# Altium schematic sheet Schem_Example.SchDoc
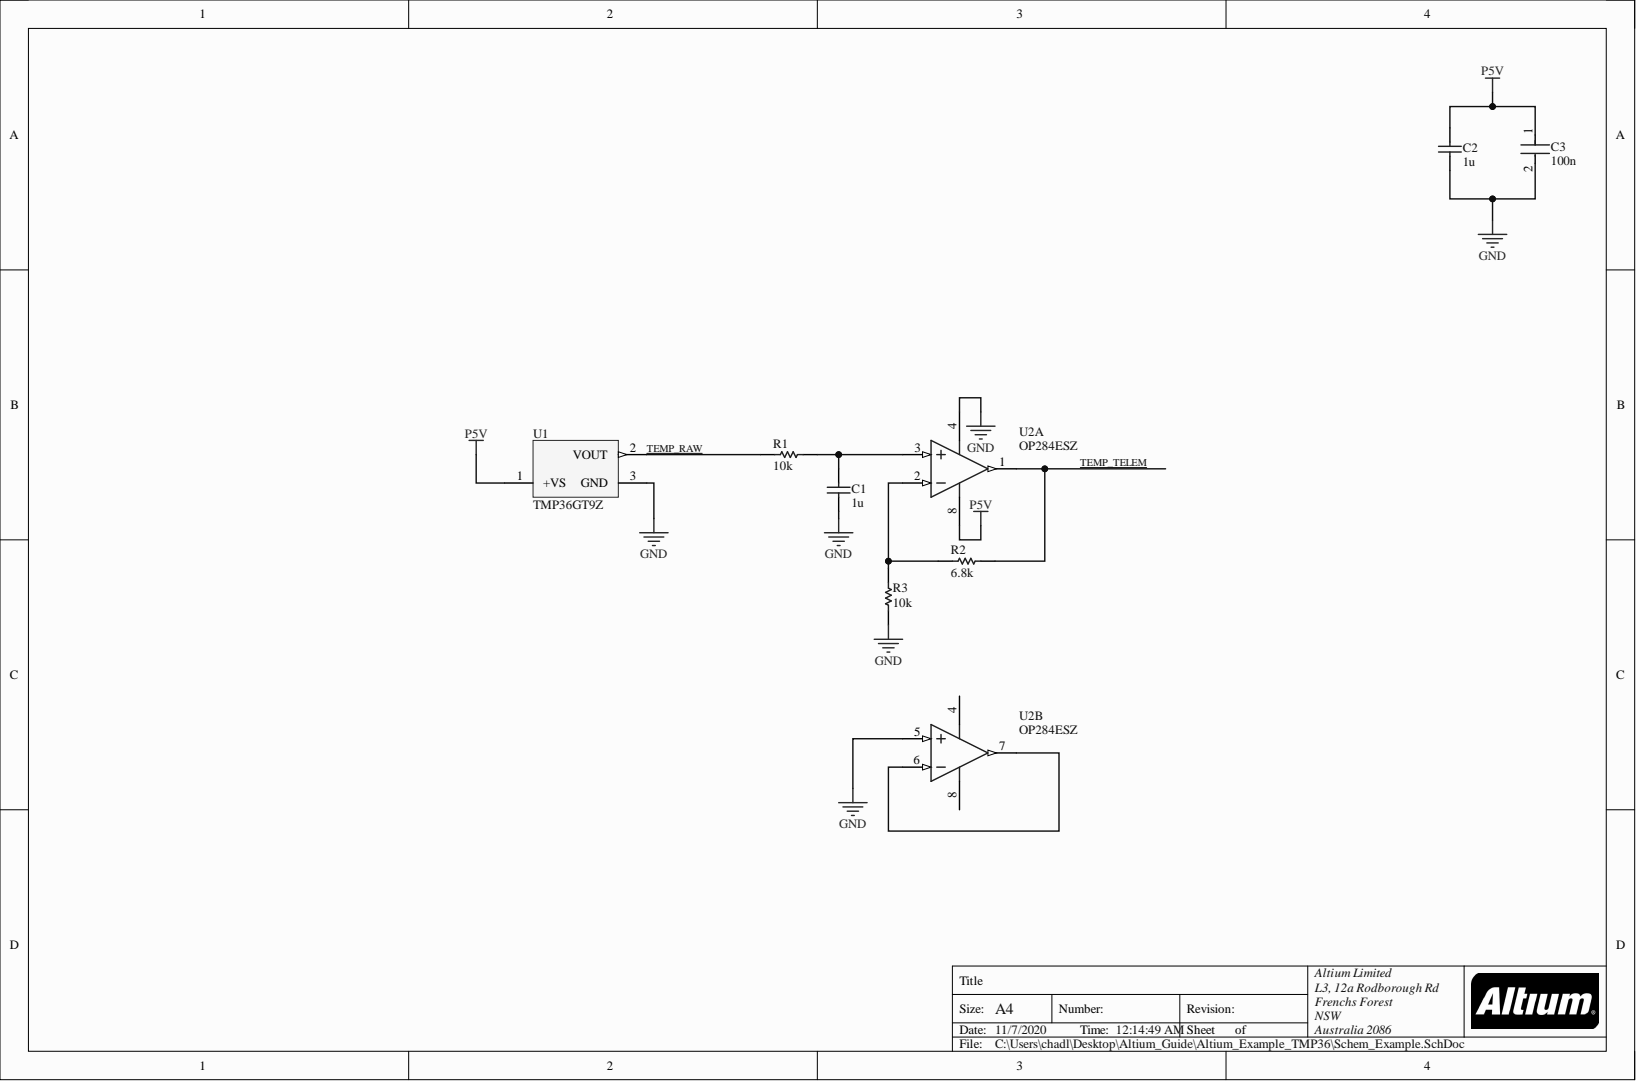
[diagram p0: Schem_Example.SchDoc]

## Parts
C1  1u  [CAPC1608X90X35NL15T15]
C2  1u  [CAPC1608X90X35NL15T15]
C3  100n  [FP-C0402-BB-MFG]
R1  10k  [RESC1609X50X30NL8T20]
R2  6.8k  [RESC1609X50X30LL10T20]
R3  10k  [RESC1609X50X30NL8T20]
U1  TMP36GT9Z  [ADI-T-3]
U2  OP284ESZ  [ADI-R-8_L]  (parts A,B)

## Nets
GND: C1.1 C2.1 C3.2 R3.1 U1.3 U2.4 U2.5
P5V: C2.2 C3.1 U1.1 U2.8
NetC1_2: C1.2 R1.1 U2.3
NetR1_2: R1.2 U1.2
NetR2_1: R2.1 R3.2 U2.2
NetR2_2: R2.2 U2.1
NetU2_6: U2.6 U2.7

## Library data of the parts
C1 C2 = CMP-2006-04474-1: Manufacturer: AVX Interconnect / Elco; Part Number: 06036D105KAT2A; Component Kind: Standard; Library Name: Altium Content Vault
C3 = CMP-03020-023811-1: C0402 0.10 μF X5R 10.00% 10 V; Manufacturer: Kemet; Manufacturer Part Number: C0402C104K8PACTU; Capacitance: 0.10 μF; Material: Ceramic; Temperature Coefficient: 15%; Tolerance: 0.1; Value: 0.10 μF; Voltage Rating: 10 V; Automotive: No; Category: Cap; Component Kind: Standard; ComponentLink2Description: Datasheet; ComponentLink2URL: https://content.kemet.com/datasheets/KEM_C1002_X7R_SMD.pdf; Device Class L1: Passive Components; Device Class L2: Capacitors; Device Class L3: Ceramic Capacitors; Digikey Description: CAP CER 0.1UF 10V X5R 0402; Height: 0.55mm; Ipc Land Pattern Name: CAPC10050X50; Lead Free: Yes; Library Name: Altium Content Vault; Mouser Description: Capacitor 100 nF 10 VDC 0402; Octopart: https://octopart.com/c0402c104k8pactu-kemet-140039; Package: C0402-BB; RoHS: TRUE; Temperature: -55°C to +125°C; Temperature Characteristic: X5R; Temperature Range High: +125°C; Temperature Range Low: -55°C
R1 R3 = CMP-1666-00005-4: Chip Resistor, 10 KOhm, +/- 1%, 100 mW, -55 to 155 degC, 0603 (1608 Metric), RoHS, Tape and Reel; Manufacturer: Vishay Semiconductor; Voltage Rating: 75 V; Component Kind: Standard; ComponentLink1Description: Manufacturer URL; ComponentLink1URL: http://www.vishay.com/; ComponentLink2Description: Datasheet; ComponentLink2URL: https://datasheet.ciiva.com/11809/0900766b80d98afc-11809037.pdf; DatasheetVersion: 06/2012; Height: 0.45 mm; Length: 1.55 mm; Library Name: Altium Content Vault; Max Operating Temperature: 155 degC; Min Operating Temperature: -55 degC; Mounting Technology: Surface Mount; Package Quantity: 5000; PackageDescription: 2-Pin Surface Mount Device, Body 1.575 x 0.85 mm; PackageReference: 0603; Packaging: Tape and Reel; REACH SVHC: Unknown; RoHSCompliant: true
R2 = CMP-2000-03550-1: Manufacturer: Vishay Dale; Part Number: CRCW06036K80FKEA; Component Kind: Standard; Library Name: Altium Content Vault
U1 = CMP-0887-00067-1: Low Voltage Temperature Sensor, 2.7 to 5.5 V Supply, Industrial, 3-pin TO92 (T-3), Tray; Manufacturer: Analog Devices; Code_JEDEC: TO-226-AA; Component Kind: Standard; ComponentLink1Description: Manufacturer URL; ComponentLink1URL: http://www.analog.com/en/index.html; ComponentLink2Description: Datasheet; ComponentLink2URL: http://www.analog.com/static/imported-files/data_sheets/TMP35_36_37.pdf; ComponentLink3Description: Package Specification; ComponentLink3URL: http://www.analog.com/static/imported-files/packages/PKG_PDF/TO-92(T)/T_3.pdf; ComponentLink4Description: Product URL; ComponentLink4URL: http://www.analog.com/en/mems-sensors/digital-temperature-sensors/tmp36/products/product.html; DatasheetVersion: Rev. F; Library Name: Altium Content Vault; Mounting Technology: Through Hole; PackageDescription: 3-Pin Plastic Header-Style Package, 1.27 mm Pitch; PackageReference: T-3; PackageVersion: 042208-A, 04/2008; Packing: Tray; PartNumber: TMP36GT9Z; Supply Voltage Range: 2.7 to 5.5 V
U2 = CMP-0384-00701-1: Double Channel Single-Supply Operation Operational Amplifier, 4.25 MHz BW, 4 V/us SR, Industrial, 8-pin SOIC (R-8), Tube; Generic Part Number: OP284; Manufacturer: Analog Devices; Amplifiers Per Package: 2; Input Bias Current: 80nA; Input Offset Voltage: 175uV; Iq/Amp: 2mA; Slew Rate: 4V/us; V Span Max: 36V; V Span Min: 3V; Code_JEDEC: MS-012-AA; Component Kind: Standard; ComponentLink1Description: Manufacturer URL; ComponentLink1URL: http://www.analog.com/en/index.html; ComponentLink2Description: Datasheet; ComponentLink2URL: http://www.analog.com/static/imported-files/data_sheets/OP184_284_484.pdf; ComponentLink3Description: Package Specification; ComponentLink3URL: http://www.analog.com/static/imported-files/packages/PKG_PDF/SOIC_Narrow%20(R)/R_8.pdf; DatasheetVersion: Rev. J; Library Name: Altium Content Vault; Mounting Technology: Surface Mount; PackageDescription: 8-Pin Standard Small Outline Package, Body 3.9 x 4.9 mm, Pitch 1.27 mm; PackageReference: R-8; PackageVersion: 060506-A; PartNumber: OP284ESZ; Small Signal Bandwidth: 4.25MHz
